# Altium schematic sheet edu22_fpga.SchDoc(edu22_fpga)
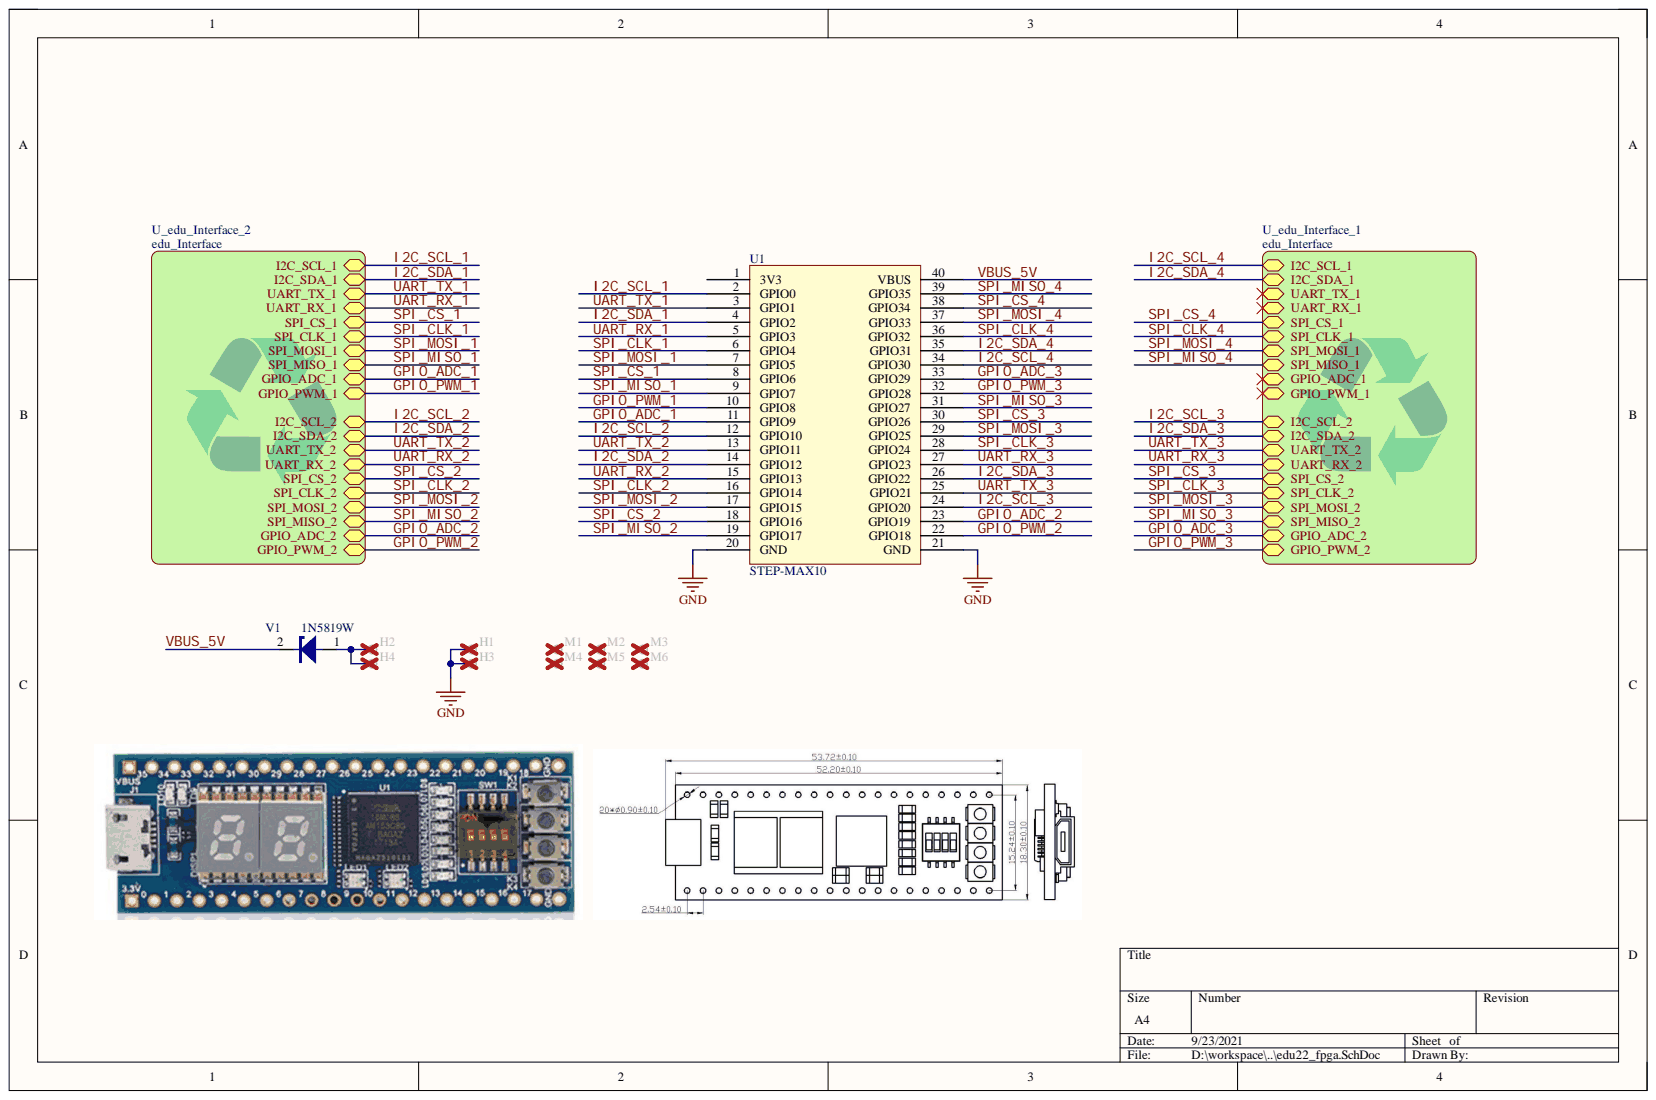
[diagram p0: edu22_fpga.SchDoc]

## Parts
H1  M3  [MH-3.3]  (value not drawn)
H2  M3  [MH-3.3]  (value not drawn)
H3  M3  [MH-3.3]  (value not drawn)
H4  M3  [MH-3.3]  (value not drawn)
M1  MARK  [MARK]  (value not drawn)
M2  MARK  [MARK]  (value not drawn)
M3  MARK  [MARK]  (value not drawn)
M4  MARK  [MARK]  (value not drawn)
M5  MARK  [MARK]  (value not drawn)
M6  MARK  [MARK]  (value not drawn)
U1  STEP-MAX10  [STEP-MAX10-DIP40]
V1  1N5819W  [SOD-123]

## Nets
GND: H1.1 H3.1 U1.20(GND) U1.21(GND)
GPIO_ADC_1: U1.11(GPIO9)
GPIO_ADC_2: U1.23(GPIO19)
GPIO_ADC_3: U1.33(GPIO29)
GPIO_PWM_1: U1.10(GPIO8)
GPIO_PWM_2: U1.22(GPIO18)
GPIO_PWM_3: U1.32(GPIO28)
I2C_SCL_1: U1.2(GPIO0)
I2C_SCL_2: U1.12(GPIO10)
I2C_SCL_3: U1.24(GPIO20)
I2C_SCL_4: U1.34(GPIO30)
I2C_SDA_1: U1.4(GPIO2)
I2C_SDA_2: U1.14(GPIO12)
I2C_SDA_3: U1.26(GPIO22)
I2C_SDA_4: U1.35(GPIO31)
SPI_CLK_1: U1.6(GPIO4)
SPI_CLK_2: U1.16(GPIO14)
SPI_CLK_3: U1.28(GPIO24)
SPI_CLK_4: U1.36(GPIO32)
SPI_CS_1: U1.8(GPIO6)
SPI_CS_2: U1.18(GPIO16)
SPI_CS_3: U1.30(GPIO26)
SPI_CS_4: U1.38(GPIO34)
SPI_MISO_1: U1.9(GPIO7)
SPI_MISO_2: U1.19(GPIO17)
SPI_MISO_3: U1.31(GPIO27)
SPI_MISO_4: U1.39(GPIO35)
SPI_MOSI_1: U1.7(GPIO5)
SPI_MOSI_2: U1.17(GPIO15)
SPI_MOSI_3: U1.29(GPIO25)
SPI_MOSI_4: U1.37(GPIO33)
UART_RX_1: U1.5(GPIO3)
UART_RX_2: U1.15(GPIO13)
UART_RX_3: U1.27(GPIO23)
UART_TX_1: U1.3(GPIO1)
UART_TX_2: U1.13(GPIO11)
UART_TX_3: U1.25(GPIO21)
VBUS_5V: U1.40(VBUS) V1.2
NetH2_1: H2.1 H4.1 V1.1
NetM1_1: M1.1
NetM2_1: M2.1
NetM3_1: M3.1
NetM4_1: M4.1
NetM5_1: M5.1
NetM6_1: M6.1
NetU1_1: U1.1(3V3)

## Library data of the parts
H1-H4 = MH: Mounting Hole; Component Kind: Standard; Library Name: General_001.IntLib
U1 = STEP-MAX10: FPGA core; Component Kind: Standard; Library Name: General_001.IntLib
V1 = Diode: Zener Diode; BOM_Supplier Part: C169540; Component Kind: Standard; Library Name: General_001.IntLib
M1-M6 = MARK: Component Kind: Standard; Description: Mark; Library Name: General_001.IntLib
